# Altium schematic sheet Relay.SchDoc
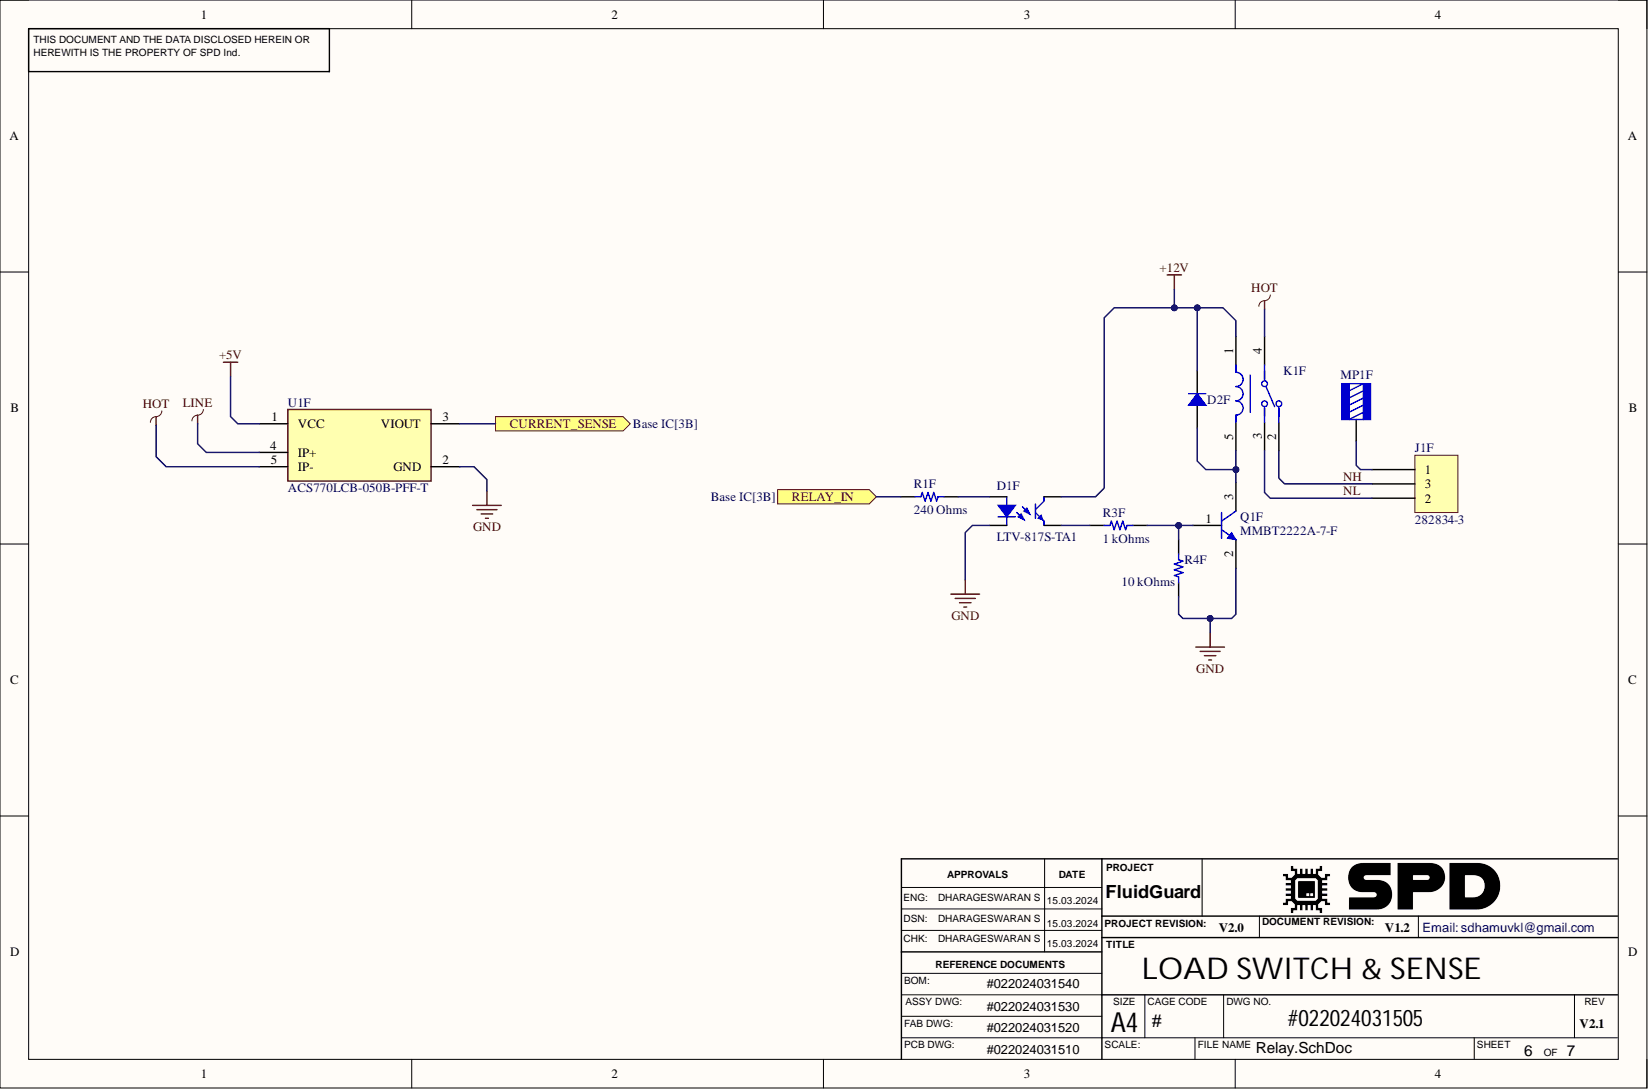
[diagram p0: Relay.SchDoc]

## Parts
D1F  LTV-817S-TA1  [LITEON LTV-817]
D2F  S2A-13-F  [DO214AA SMB]  (value not drawn)
J1F  282834-3  [TE 282834-3]
K1F  G2R-1-DC12  [FP-G2R-1-DC12-MFG]  (value not drawn)
MP1F  74650173R  [WURTH 74650173]  (value not drawn)
Q1F  MMBT2222A-7-F  [DIODES SOT-23-3]
R1F  240 Ohms  [RES 0603_1608]
R3F  1 kOhms  [RES 0603_1608]
R4F  10 kOhms  [RES 0603_1608]
U1F  ACS770LCB-050B-PFF-T  [FP-CB-5-PFF-MFG]

## Ports
CURRENT_SENSE, RELAY_IN

## Nets
+5V: U1F.1(VCC)
+12V: D1F.4 D2F.2 K1F.1
GND: D1F.2 Q1F.2 R4F.1 U1F.2(GND)
HOT: K1F.4 U1F.5(IP-)
LINE: U1F.4(IP+)
CURRENT_SENSE: U1F.3(VIOUT) ; port CURRENT_SENSE
NH: J1F.3 K1F.2
NL: J1F.2 K1F.3
RELAY_IN: R1F.1 ; port RELAY_IN
NetD1F_1: D1F.1 R1F.2
NetD1F_3: D1F.3 R3F.1
NetD2F_1: D2F.1 K1F.5 Q1F.3
NetJ1F_1: J1F.1 MP1F.1
NetQ1F_1: Q1F.1 R3F.2 R4F.2

## Library data of the parts
D1F = LTV-817S-TA1: OPTOISOLATR 5KV TRANSISTOR 4-SMD; Manufacturer: Lite-On Inc.; Current - Dc Forward (If) (Max): 50mA; Current Transfer Ratio (Max): 600% @ 5mA; Current Transfer Ratio (Min): 50% @ 5mA; Database Table Name: altium.Optoisolators-TransistorPhotovoltaic; id: 2; Input Type: DC; Number Of Channels: 1; Rise / Fall Time (Typ): 4µs, 3µs; Vce Saturation (Max): 200mV; Voltage - Forward (Vf) (Typ): 1.2V; Voltage - Isolation: 5000Vrms; Voltage - Output (Max): 35V; Component Kind: Standard; ComponentLink1Description: Datasheet; ComponentLink1URL: http://optoelectronics.liteon.com/upload/download/DS-70-96-0016/LTV-8X7%20series%20201610%20.pdf; ComponentLink2Description: DigiKey Link; ComponentLink2URL: http://digikey.com/product-detail/en/lite-on-inc/LTV-817S-TA1/160-1893-1-ND/3306757; Current - Output / Channel: 50mA; Device Package: 4-SMD, Gull Wing; LastUpdated: 2020-01-12T21:58:48.100; Lifecycle Status: Active; Minimum Order: 1; Mounting Type: Surface Mount; Operating Temperature: -30°C ~ 110°C; Output Type: Transistor; Package / Case: 4-SMD, Gull Wing; Packaging: TapeAndReel; Part Number: LTV-817S-TA1; Part Status: Active; Price: 0.12; Series: -; Supplier 1: DigiKey; Supplier Device Package: 4-SMD; Supplier Part Number 1: 160-1893-1-ND; Library Name: Postgres Altium Library - altium_library.DbLib
D2F = S2A-13-F: DIODE GEN PURP 50V 1.5A SMB; Manufacturer: Diodes Incorporated; Capacitance @ Vr, F: 20pF @ 4V, 1MHz; Current - Reverse Leakage @ Vr: 5 µA @ 50 V; Database Table Name: altium.Diodes-Rectifiers-Single; Diode Type: Standard; id: 302; Speed: Standard Recovery >500ns, > 200mA (Io); Voltage - Forward (Vf) (Max) @ If: 1.15 V @ 1.5 A; Component Kind: Standard; ComponentLink1Description: Datasheet; ComponentLink1URL: https://www.diodes.com/assets/Datasheets/ds16004.pdf; ComponentLink2Description: DigiKey Link; ComponentLink2URL: https://www.digikey.com/en/products/detail/diodes-incorporated/S2A-13-F/814429; Current - Average Rectified (Io): 1.5A; LastUpdated: 2023-01-02T20:53:07.120; Lifecycle Status: Active; Minimum Order: 1; Mounting Type: Surface Mount; Operating Temperature - Junction: -65°C ~ 150°C; Package / Case: DO-214AA, SMB; Packaging: TapeAndReel; Part Number: S2A-13-F; Part Status: Active; PartId: 302; Price: 0.05; Series: -; Supplier 1: DigiKey; Supplier Device Package: SMB; Supplier Part Number 1: S2A-FDICT-ND; Voltage - DC Reverse (Vr) (Max): 50 V; Library Name: Postgres Altium Library - altium_library.DbLib
J1F = 282834-3: TERM BLK 3P SIDE ENT 2.54MM PCB; Manufacturer: TE Connectivity AMP Connectors; Current: 10A; Database Table Name: altium.Connectors-TerminalBlocks-WireToBoard; id: 2; Mating Orientation: Horizontal with Board; Number Of Levels: 1; Pitch: 0.100" (2.54mm); Positions Per Level: 3; Voltage: 150V; Wire Gauge: 16-30 AWG; Wire Termination: Screw - Rising Cage Clamp; Color: Green; Component Kind: Standard; ComponentLink1Description: Datasheet; ComponentLink1URL: https://www.te.com/commerce/DocumentDelivery/DDEController?Action=srchrtrv&DocNm=282834&DocType=Customer+Drawing&DocLang=English; ComponentLink2Description: DigiKey Link; ComponentLink2URL: http://digikey.com/product-detail/en/te-connectivity-amp-connectors/282834-3/A98334-ND/1153264; LastUpdated: 2020-01-15T04:15:50.317; Lifecycle Status: Active; Minimum Order: 1; Mounting Type: Through Hole; Packaging: Bulk; Part Number: 282834-3; Part Status: Active; Price: 2.01; Series: Buchanan; Supplier 1: DigiKey; Supplier Part Number 1: A98334-ND; Library Name: Postgres Altium Library - altium_library.DbLib
K1F = CMP-08822-000109-1: RELAY GEN PURPOSE SPDT 10A 12V; Coil Current: 43.6mA; Coil Power: 530mW; Coil Resistance: 275R; Coil Type: Non-Latching; Max Voltage Rating (AC): 380V; Max Voltage Rating (DC): 125V; Operate Time: 15ms; Relay Type: General Purpose; Release Time: 5ms; Coil Voltage (DC): 12V; Component Kind: Standard; Contact Current Rating: 10A; Contact Material: Silver; Depth: 13mm; Height: 25.3mm; Lead Free: Lead Free; Length: 29mm; Library Name: Altium Content Vault; Max Current Rating: 10A; Max Operating Temperature: 70°C; Min Operating Temperature: -40°C; Mount: Through Hole; Number of Pins: 5; Package Quantity: 100; Packaging: Tray; Power Consumption: 530mW; Power Rating: 530mW; Radiation Hardening: No; REACH SVHC: No SVHC; Series: G2R; Termination: PC Pin; Throw Configuration: SPDT; Voltage Rating (AC): 380V; Weight: 17g; Width: 13mm
MP1F = 74650173R: TERM REDCUBE M3 4PIN PCB; Manufacturer: Würth Elektronik; Current Rating (Amps): 50 A; Database Table Name: altium.Connectors-ScrewTerminals; id: 4; Component Kind: Standard; ComponentLink1Description: Datasheet; ComponentLink1URL: https://katalog.we-online.de/em/datasheet/74650173R.pdf; ComponentLink2Description: DigiKey Link; ComponentLink2URL: https://www.digikey.com/en/products/detail/würth-elektronik/74650173R/6643981; Features: Screw not Included; LastUpdated: 2022-08-07T20:42:53.530; Lifecycle Status: Active; Minimum Order: 1; Mounting Type: Through Hole; Number Of Pins: 4; Packaging: TapeAndReel; Part Number: 74650173R; Part Status: Active; Price: 2.63; Screw Size: M3; Series: REDCUBE WP-THRBU; Supplier 1: DigiKey; Supplier Part Number 1: 732-10885-1-ND; Terminal Type: Screw Terminal, Power Tap; Termination: Solder; Library Name: Postgres Altium Library - altium_library.DbLib
Q1F = MMBT2222A-7-F: TRANS NPN 40V 0.6A SOT23-3; Manufacturer: Diodes Incorporated; Current - Collector (Ic) (Max): 600 mA; Current - Collector Cutoff (Max): 10nA (ICBO); Database Table Name: altium.Transistors-Bipolar-BJT-Single; DC Current Gain (Hfe) (Min) @ Ic, Vce: 100 @ 150mA, 10V; Frequency - Transition: 300MHz; id: 84; Power - Max: 310 mW; Vce Saturation (Max) @ Ib, Ic: 1V @ 50mA, 500mA; Component Kind: Standard; ComponentLink1Description: Datasheet; ComponentLink1URL: https://www.diodes.com/assets/Datasheets/ds30041.pdf; ComponentLink2Description: DigiKey Link; ComponentLink2URL: https://www.digikey.com/en/products/detail/diodes-incorporated/MMBT2222A-7-F/814503; LastUpdated: 2023-01-02T21:11:05.533; Lifecycle Status: Active; Minimum Order: 1; Mounting Type: Surface Mount; Operating Temperature: -55°C ~ 150°C (TJ); Package / Case: TO-236-3, SC-59, SOT-23-3; Packaging: TapeAndReel; Part Number: MMBT2222A-7-F; Part Status: Active; PartId: 84; Price: 0.02; Series: Automotive, AEC-Q101; Supplier 1: DigiKey; Supplier Device Package: SOT-23-3; Supplier Part Number 1: MMBT2222A-FDICT-ND; Transistor Type: NPN; Voltage - Collector Emitter Breakdown (Max): 40 V; Library Name: Postgres Altium Library - altium_library.DbLib
R1F = RK73B1JTTD241J: RES 240 OHM 5% 1/8W 0603; Manufacturer: KOA Speer Electronics, Inc.; Database Table Name: altium.Resistors-SurfaceMount-0603; id: 492; Power (Watts): 0.125W, 1/8W; Temperature Coefficient: ±200ppm/°C; Component Kind: Standard; ComponentLink1Description: Datasheet; ComponentLink1URL: http://www.koaspeer.com/catimages/Products/RK73B/RK73B.pdf; ComponentLink2Description: DigiKey Link; ComponentLink2URL: https://www.digikey.com/en/products/detail/koa-speer-electronics-inc/RK73B1JTTD241J/9844758; Composition: Thick Film; Device Package: 0603 (1608 Metric); Features: Automotive AEC-Q200; Height - Seated (Max): 0.022" (0.55mm); LastUpdated: 2023-01-02T20:30:22.853; Lifecycle Status: Active; Minimum Order: 1; Number Of Terminations: 2; Operating Temperature: -55°C ~ 155°C; Package / Case: 0603 (1608 Metric); Packaging: CutTape; Part Number: RK73B1JTTD241J; Part Status: Active; PartId: 5905; Price: 0.01; Ratings: AEC-Q200; Resistance: 240 Ohms; Series: RK73B; Size / Dimension: 0.063" L x 0.031" W (1.60mm x 0.80mm); Supplier 1: DigiKey; Supplier Device Package: 0603; Supplier Part Number 1: 2019-RK73B1JTTD241JCT-ND; Tolerance: ±5%; Value: 240 Ohms; Library Name: Postgres Altium Library - altium_library.DbLib
R3F = AT0603DRD071KL: RES SMD 1K OHM 0.5% 1/10W 0603; Manufacturer: Yageo; Database Table Name: altium.Resistors-SurfaceMount-0603; id: 26; Power (Watts): 0.1W, 1/10W; Temperature Coefficient: ±25ppm/°C; Component Kind: Standard; ComponentLink1Description: Datasheet; ComponentLink1URL: http://www.yageo.com/documents/recent/PYu-AT_51_RoHS_L_5.pdf; ComponentLink2Description: DigiKey Link; ComponentLink2URL: https://www.digikey.com/en/products/detail/yageo/AT0603DRD071KL/5138634; Composition: Thin Film; Device Package: 0603 (1608 Metric); Features: Anti-Sulfur, Automotive AEC-Q200, Moisture Resistant; Height - Seated (Max): 0.022" (0.55mm); LastUpdated: 2023-01-02T20:30:26.797; Lifecycle Status: Active; Minimum Order: 1; Number Of Terminations: 2; Operating Temperature: -55°C ~ 155°C; Package / Case: 0603 (1608 Metric); Packaging: TapeAndReel; Part Number: AT0603DRD071KL; Part Status: Active; PartId: 4899; Price: 0.02; Ratings: AEC-Q200; Resistance: 1 kOhms; Series: AT; Size / Dimension: 0.063" L x 0.031" W (1.60mm x 0.80mm); Supplier 1: DigiKey; Supplier Device Package: 0603; Supplier Part Number 1: YAG2115CT-ND; Tolerance: ±0.5%; Value: 1 kOhms; Library Name: Postgres Altium Library - altium_library.DbLib
R4F = CPF0603B10KE: RES SMD 10K OHM 0.1% 1/16W 0603; Manufacturer: TE Connectivity Passive Product; Database Table Name: altium.Resistors-SurfaceMount-0603; id: 14; Power (Watts): 0.063W, 1/16W; Temperature Coefficient: ±25ppm/°C; Component Kind: Standard; ComponentLink1Description: Datasheet; ComponentLink1URL: https://www.te.com/commerce/DocumentDelivery/DDEController?Action=srchrtrv&DocNm=1773200&DocType=DS&DocLang=English; ComponentLink2Description: DigiKey Link; ComponentLink2URL: https://www.digikey.com/en/products/detail/te-connectivity-passive-product/CPF0603B10KE/2389826; Composition: Thin Film; Device Package: 0603 (1608 Metric); Height - Seated (Max): 0.022" (0.55mm); LastUpdated: 2023-01-02T20:30:26.913; Lifecycle Status: Active; Minimum Order: 1; Number Of Terminations: 2; Operating Temperature: -55°C ~ 155°C; Package / Case: 0603 (1608 Metric); Packaging: TapeAndReel; Part Number: CPF0603B10KE; Part Status: Active; PartId: 4872; Price: 0.09; Resistance: 10 kOhms; Series: CPF, Neohm; Size / Dimension: 0.061" L x 0.031" W (1.55mm x 0.80mm); Supplier 1: DigiKey; Supplier Device Package: 0603; Supplier Part Number 1: A119886CT-ND; Tolerance: ±0.1%; Value: 10 kOhms; Library Name: Postgres Altium Library - altium_library.DbLib
U1F = CMP-82923-000004-3: SENSOR CURRENT HALL 50A AC/DC; Accuracy: 0.6%; Frequency: 120kHz; Linearity: 1%; Max Input Voltage: 5.5V; Max Supply Current: 15mA; Min Input Voltage: 4.5V; Number of Channels: 1; Polarity: Bidirectional; Response Time: 4.6us; Sensitivity: 40mV/A; Component Kind: Standard; Current Sensing: 50A; Height: 7mm; Length: 14mm; Library Name: Altium Content Vault; Max Operating Temperature: 150°C; Min Operating Temperature: -40°C; Mount: Through Hole; Number of Pins: 5; Package Quantity: 34; Packaging: Rail/Tube; RoHS Compliant: Yes; Width: 13mm
